# Altium schematic sheet [10]-FLASH,SD.SchDoc
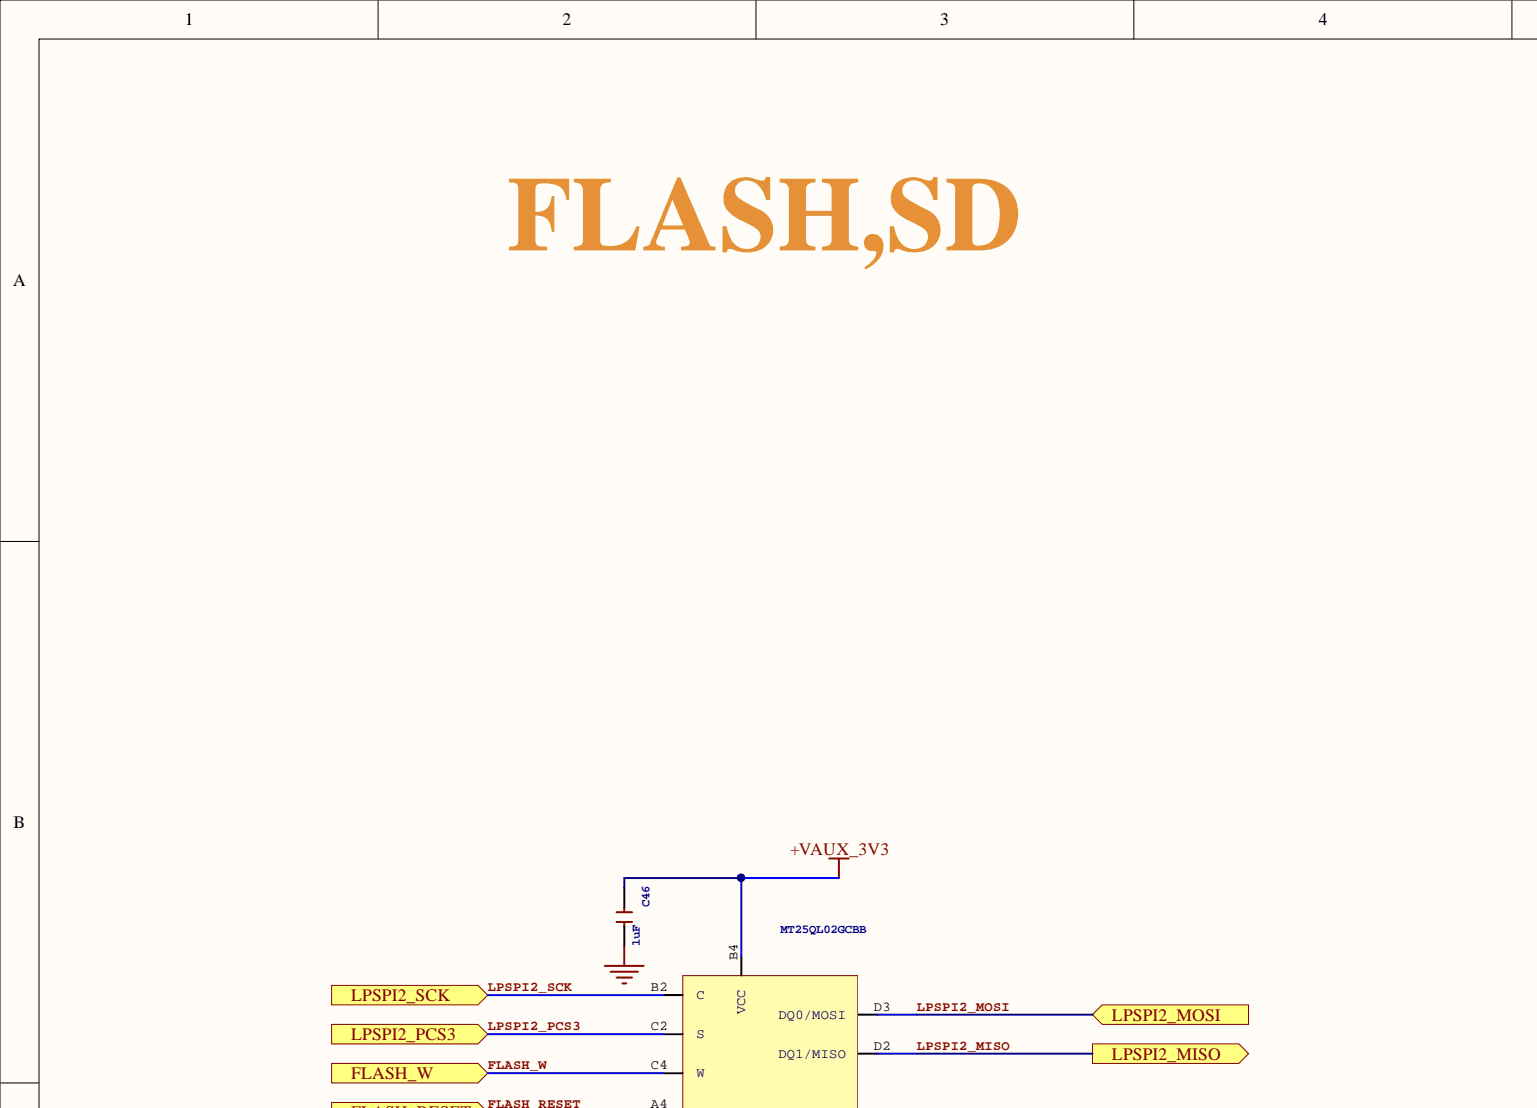
[diagram p0: [10]-FLASH,SD.SchDoc, top left]
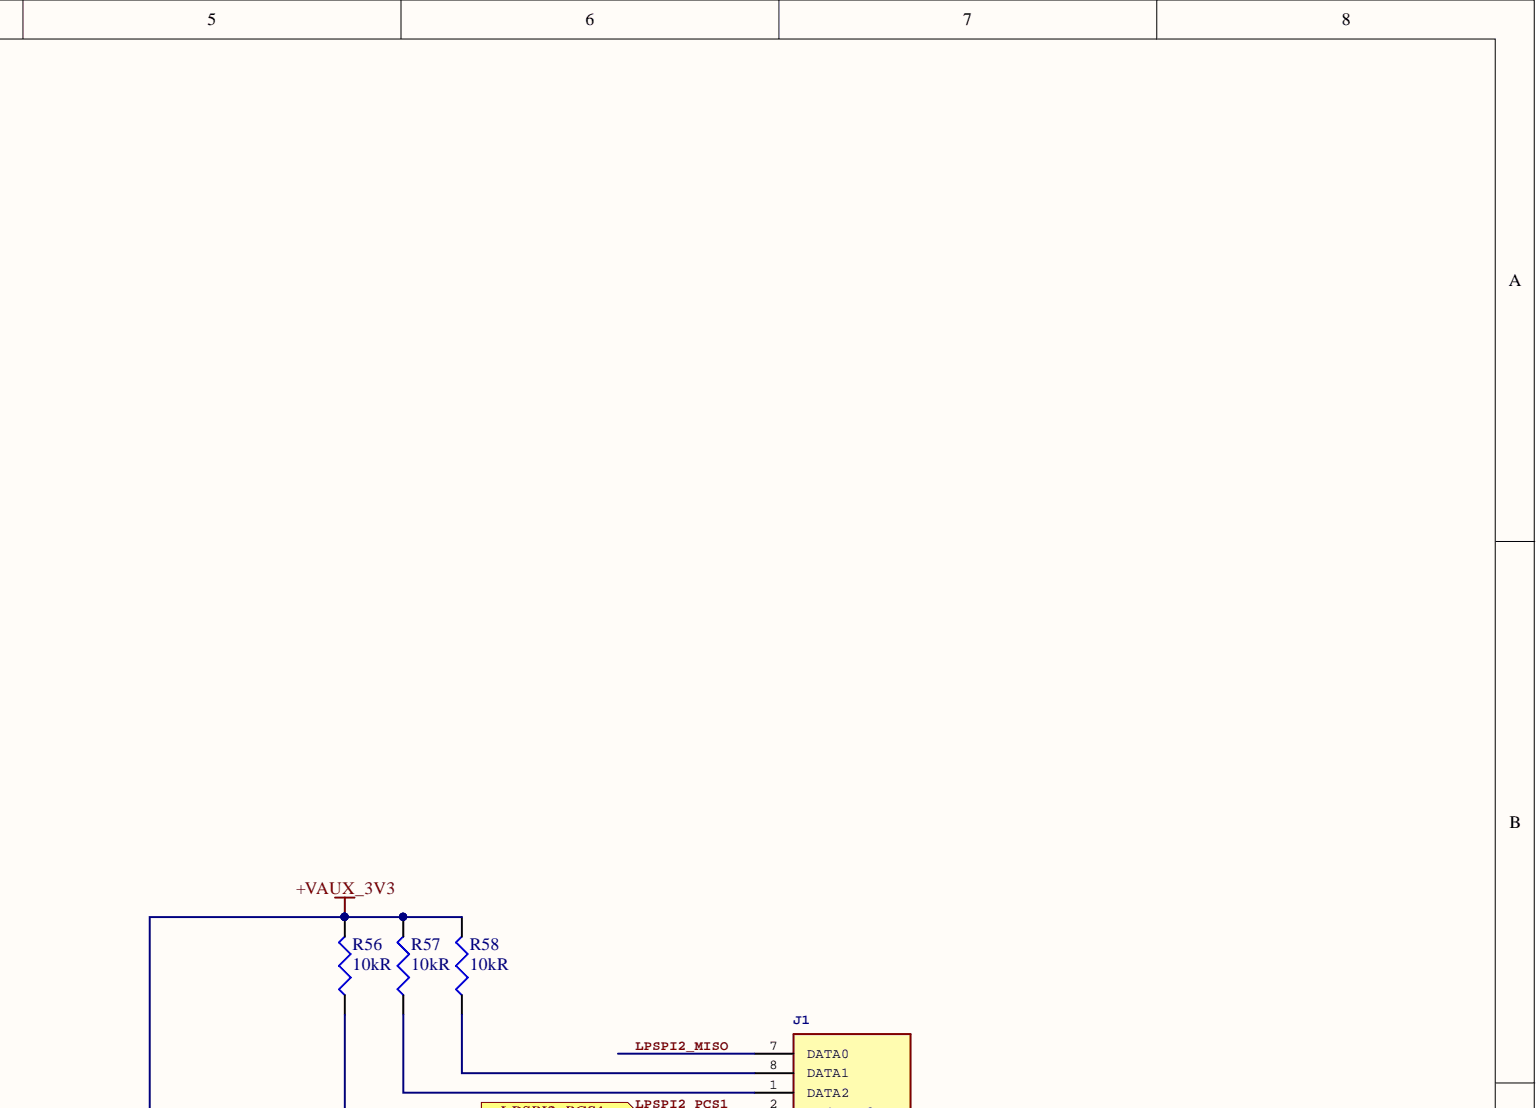
[diagram p1: [10]-FLASH,SD.SchDoc, top right]
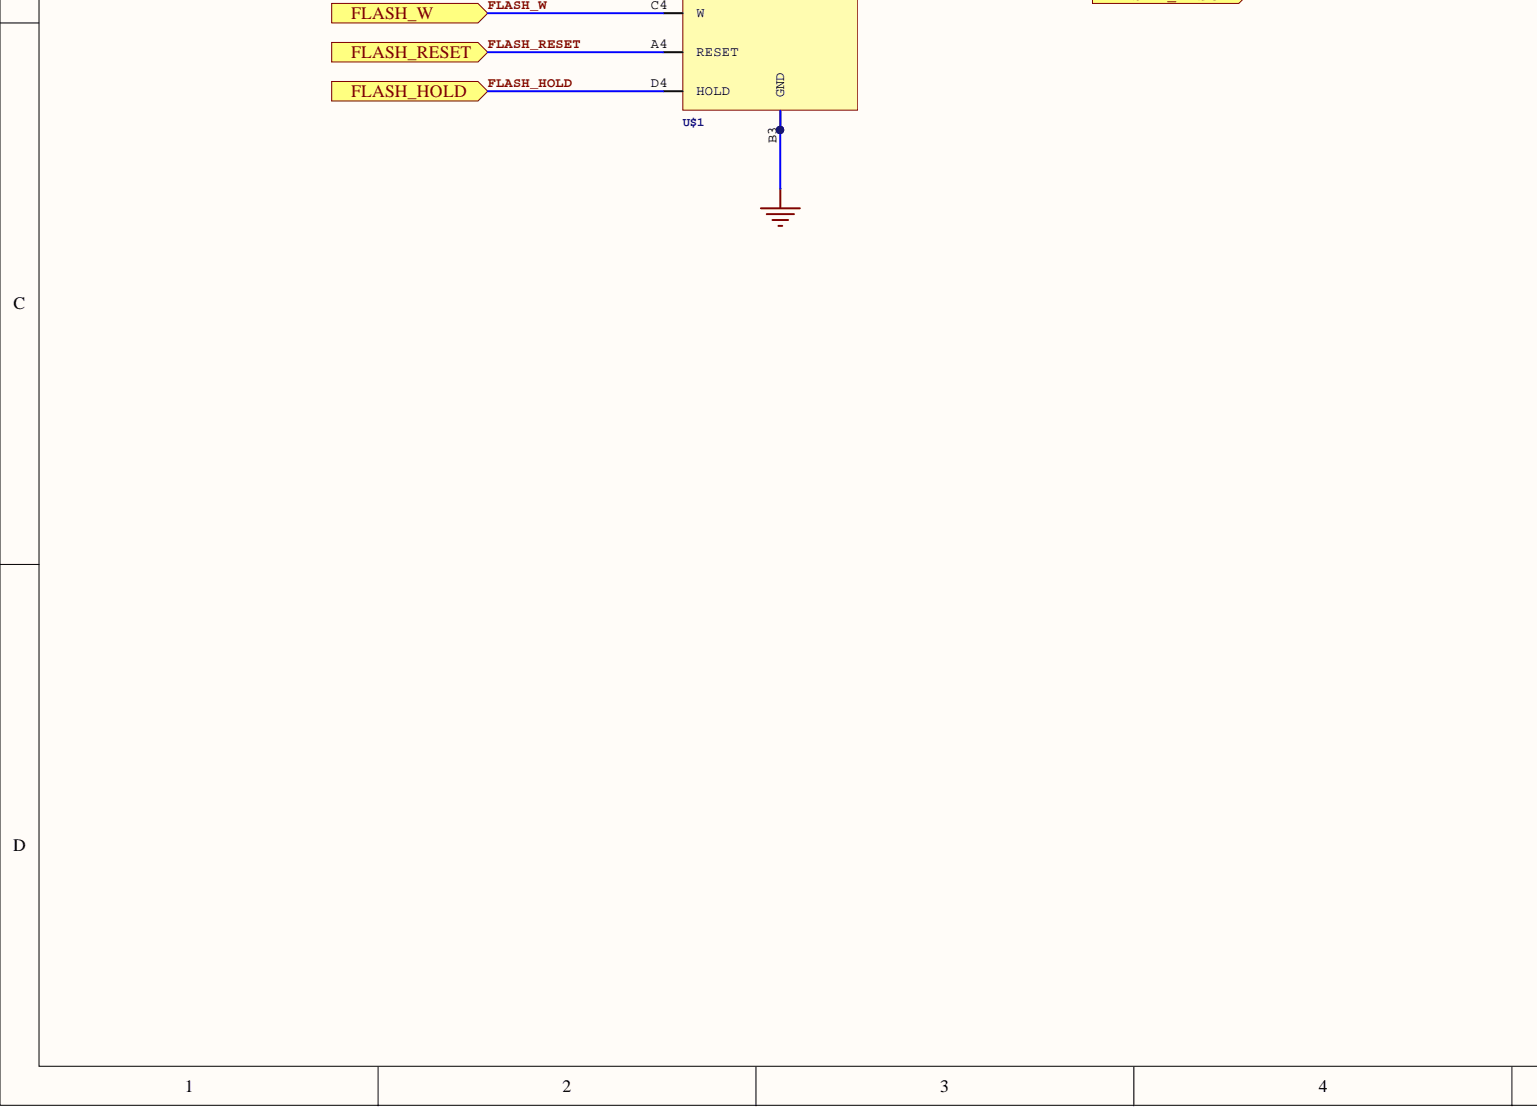
[diagram p2: [10]-FLASH,SD.SchDoc, bottom left]
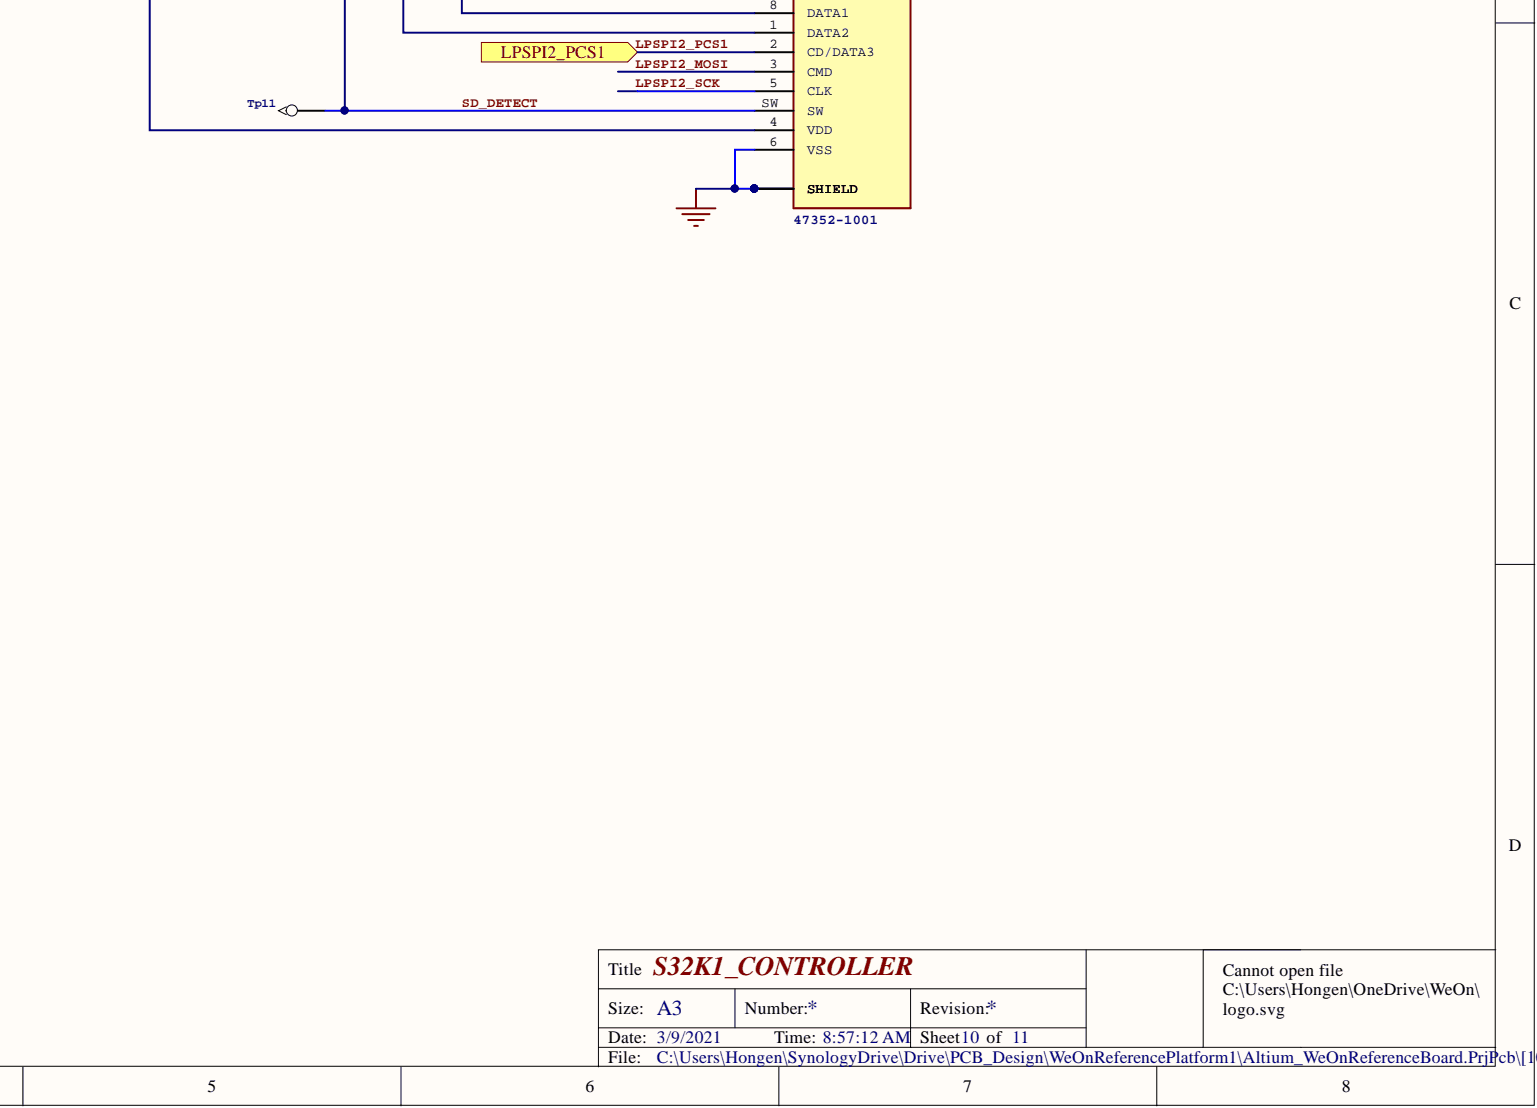
[diagram p3: [10]-FLASH,SD.SchDoc, bottom right]

## Parts
C46  1uF  [C0805]
J1  47352-1001  [MOLEX_47352-1001]
R56  Resistance: 10kR  [RES0402]  (hidden comment: ERJ-2GEJ103X)
R57  Resistance: 10kR  [RES0402]  (hidden comment: ERJ-2GEJ103X)
R58  Resistance: 10kR  [RES0402]  (hidden comment: ERJ-2GEJ103X)
Tp11  TP  [TestPoint]  (value not drawn)
U$1  MT25QL02GCBB  [BGA24C100P5X5_600X800X155]

## Ports
FLASH_HOLD, FLASH_RESET, FLASH_W, LPSPI2_MISO, LPSPI2_MOSI, LPSPI2_PCS1, LPSPI2_PCS3, LPSPI2_SCK

## Nets
+VAUX_3V3: C46.1 J1.4(VDD) R56.2 R57.2 R58.2 U$1.B4(VCC)
GND: C46.2 J1.6(VSS) J1.S1(SHIELD) J1.S2 J1.S3 J1.S4 U$1.B3(GND)
FLASH_HOLD: U$1.D4(HOLD) ; port FLASH_HOLD
FLASH_RESET: U$1.A4(RESET) ; port FLASH_RESET
FLASH_W: U$1.C4(W) ; port FLASH_W
LPSPI2_MISO: J1.7(DATA0) U$1.D2(DQ1/MISO) ; port LPSPI2_MISO
LPSPI2_MOSI: J1.3(CMD) U$1.D3(DQ0/MOSI) ; port LPSPI2_MOSI
LPSPI2_PCS1: J1.2(CD/DATA3) ; port LPSPI2_PCS1
LPSPI2_PCS3: U$1.C2(S) ; port LPSPI2_PCS3
LPSPI2_SCK: J1.5(CLK) U$1.B2(C) ; port LPSPI2_SCK
SD_DETECT: J1.SW R56.1 Tp11.1
NetJ1_1: J1.1(DATA2) R57.1
NetJ1_8: J1.8(DATA1) R58.1

## Library data of the parts
C46 = CERAMIC-100NF-50V-10%-X7R(0805): 302010165; MPN: CC0805KRX7R9BB104; GateName_1: G$1; SymbolName_1: C; Component Kind: Standard; DeviceSetName: CERAMIC-100NF-50V-10%-X7R(0805); Library Name: Seeed-Capacitor.IntLib; LibraryName: Seeed-Capacitor
J1 = 47352-1001: 1.10mm Pitch microSD Card Connector, Push-Push Type, with VSS (Supply Voltage Ground) Detect Switch, Lead-Free; GateName_1: G$1; MF: Molex; AVAILABILITY: Unavailable; Component Kind: Standard; DeviceSetName: 47352-1001; Library Name: WeOnReferenceBoard.SCHLIB; LibraryName: 47352-1001; MP: 47352-1001; PACKAGE: None; PRICE: None; SymbolName_1: 47352-1001
R56-R58 = ERJ-2GEJ103X: Res Thick Film 0402 10K Ohm 5% 1/10W ±200ppm/°C Molded SMD SMD Punched Carrier T/R; Manufacturer: Panasonic; Max Power Dissipation: 100mW; Voltage Rating: 50V; Case/Package: 0402; Case Code (Imperial): 0402; Case Code (Metric): 1005; Component Kind: Standard; ComponentLink1Description: http://industrial.panasonic.com/www-data/pdf/AOA0000/AOA0000CE1.pdf?src-supplier=Avnet+Europe; ComponentLink1URL: http://industrial.panasonic.com/www-data/pdf/AOA0000/AOA0000CE1.pdf?src-supplier=Avnet+Europe; ComponentLink2Description: http://www.farnell.com/datasheets/1678796.pdf?src-supplier=Element14; ComponentLink2URL: http://www.farnell.com/datasheets/1678796.pdf?src-supplier=Element14; ComponentLink3Description: http://www.mouser.com/ds/2/315/AOA0000CE1-37422.pdf?src-supplier=Mouser; ComponentLink3URL: http://www.mouser.com/ds/2/315/AOA0000CE1-37422.pdf?src-supplier=Mouser; ComponentLink4Description: http://www.farnell.com/datasheets/2059623.pdf?src-supplier=Farnell; ComponentLink4URL: http://www.farnell.com/datasheets/2059623.pdf?src-supplier=Farnell; ComponentLink5Description: https://www.alliedelec.com/m/d/51cd0790071e1666bdb9d00c57fcf960.pdf?src-supplier=Allied+Electronics; ComponentLink5URL: https://www.alliedelec.com/m/d/51cd0790071e1666bdb9d00c57fcf960.pdf?src-supplier=Allied+Electronics; ComponentLink6Description: http://www.jameco.com/Jameco/Products/ProdDS/1935721.pdf?src-supplier=Jameco; ComponentLink6URL: http://www.jameco.com/Jameco/Products/ProdDS/1935721.pdf?src-supplier=Jameco; ComponentLink7Description: http://industrial.panasonic.com/www-data/pdf/AOA0000/AOA0000CE1.pdf?src-supplier=Avnet; ComponentLink7URL: http://industrial.panasonic.com/www-data/pdf/AOA0000/AOA0000CE1.pdf?src-supplier=Avnet; ComponentLink8Description: http://datasheet.octopart.com/ERJ-2GEJ103X-Panasonic-datasheet-13266872.pdf?src-supplier=Newark; ComponentLink8URL: http://datasheet.octopart.com/ERJ-2GEJ103X-Panasonic-datasheet-13266872.pdf?src-supplier=Newark; ComponentLink9Description: http://datasheet.octopart.com/ERJ-2GEJ103X-Panasonic-datasheet-61114972.pdf?src-supplier=Farnell; ComponentLink9URL: http://datasheet.octopart.com/ERJ-2GEJ103X-Panasonic-datasheet-61114972.pdf?src-supplier=Farnell; ComponentLink10Description: http://datasheet.octopart.com/ERJ-2GEJ103X-Panasonic-datasheet-5314550.pdf?src-supplier=Element14; ComponentLink10URL: http://datasheet.octopart.com/ERJ-2GEJ103X-Panasonic-datasheet-5314550.pdf?src-supplier=Element14; ComponentLink11Description: http://datasheet.octopart.com/ERJ-2GEJ103X-Panasonic-datasheet-78375410.pdf; ComponentLink11URL: http://datasheet.octopart.com/ERJ-2GEJ103X-Panasonic-datasheet-78375410.pdf; ComponentLink12Description: http://datasheet.octopart.com/ERJ-2GEJ103X-Panasonic-datasheet-17720667.pdf?src-supplier=Jameco; ComponentLink12URL: http://datasheet.octopart.com/ERJ-2GEJ103X-Panasonic-datasheet-17720667.pdf?src-supplier=Jameco; ComponentLink13Description: http://datasheet.octopart.com/ERJ-2GEJ103X-Panasonic-datasheet-37173568.pdf?src-supplier=Electro+Sonic; ComponentLink13URL: http://datasheet.octopart.com/ERJ-2GEJ103X-Panasonic-datasheet-37173568.pdf?src-supplier=Electro+Sonic; ComponentLink14Description: http://datasheet.octopart.com/ERJ-2GEJ103X-Panasonic-datasheet-118598.pdf?src-supplier=Digi-Key; ComponentLink14URL: http://datasheet.octopart.com/ERJ-2GEJ103X-Panasonic-datasheet-118598.pdf?src-supplier=Digi-Key; ComponentLink15Description: http://datasheet.octopart.com/ERJ-2GEJ103X-Panasonic-datasheet-5313456.pdf?src-supplier=Farnell; ComponentLink15URL: http://datasheet.octopart.com/ERJ-2GEJ103X-Panasonic-datasheet-5313456.pdf?src-supplier=Farnell; ComponentLink16Description: http://datasheet.octopart.com/ERJ-2GEJ103X-Panasonic-datasheet-10554283.pdf?src-supplier=Farnell; ComponentLink16URL: http://datasheet.octopart.com/ERJ-2GEJ103X-Panasonic-datasheet-10554283.pdf?src-supplier=Farnell; ComponentLink17Description: http://datasheet.octopart.com/ERJ-2GEJ103X-Panasonic-datasheet-11480398.pdf?src-supplier=Arrow; ComponentLink17URL: http://datasheet.octopart.com/ERJ-2GEJ103X-Panasonic-datasheet-11480398.pdf?src-supplier=Arrow; ComponentLink18Description: http://datasheet.octopart.com/ERJ-2GEJ103X-Panasonic---ECG-datasheet-15994758.pdf?src-supplier=Farnell; ComponentLink18URL: http://datasheet.octopart.com/ERJ-2GEJ103X-Panasonic---ECG-datasheet-15994758.pdf?src-supplier=Farnell; ComponentLink19Description: http://datasheet.octopart.com/ERJ2GEJ103X-Panasonic-datasheet-27908757.pdf?src-supplier=Electro+Sonic; ComponentLink19URL: http://datasheet.octopart.com/ERJ2GEJ103X-Panasonic-datasheet-27908757.pdf?src-supplier=Electro+Sonic; ComponentLink20Description: http://datasheet.octopart.com/Thick-Film-Chip-Resistors-Panasonic-datasheet-family-105.pdf; ComponentLink20URL: http://datasheet.octopart.com/Thick-Film-Chip-Resistors-Panasonic-datasheet-family-105.pdf; Composition: Thick Film; Contact Plating: Tin; Depth: 0.5mm; Features: Automotive AEC-Q200; Height: 0.35mm; Lead Free: Lead Free; Length: 1mm; Library Name: WeOnReferenceBoard.SCHLIB; Manufacturer Part Number: ERJ-2GEJ103X; Max Operating Temperature: 155°C; Military Standard: Not; Min Operating Temperature: -55°C; Mount: Surface Mount; Number of Terminations: 2; Package Quantity: 1; Packaging: Cut Tape; Power Rating: 100mW; Pricing 1: 1 = 0.10000, 10 = 0.03100, 100 = 0.01250, 1000 = 0.00560, 2500 = 0.00486, 5000 = 0.00401 (USD); Radiation Hardening: No; REACH SVHC: No SVHC; Stock 1: 15334974; Supplier 1: Digi-Key; Supplier Part Number 1: P10KJCT-ND; Temperature Coefficient: 200ppm/°C; Termination: SMD/SMT; Tolerance: 5%; Voltage Rating (DC): 50V; Width: 0.02inch
Tp11 = TP: GateName_1: G$1; TP?: >TP_NAME; Component Kind: Standard; DeviceSetName: TP; LibraryName: WeOnReferencePlatform; SymbolName_1: TP
U$1 = MT25QL02GCBB: GateName_1: G$1; Component Kind: Standard; DeviceSetName: MT25QL02GCBB; Library Name: WeOnReferenceBoard.SCHLIB; LibraryName: WeOnReferencePlatform; SymbolName_1: MT25QL02GCBB
